# Altium schematic sheet JLINK-OB.SchDoc(JLINK-OB)
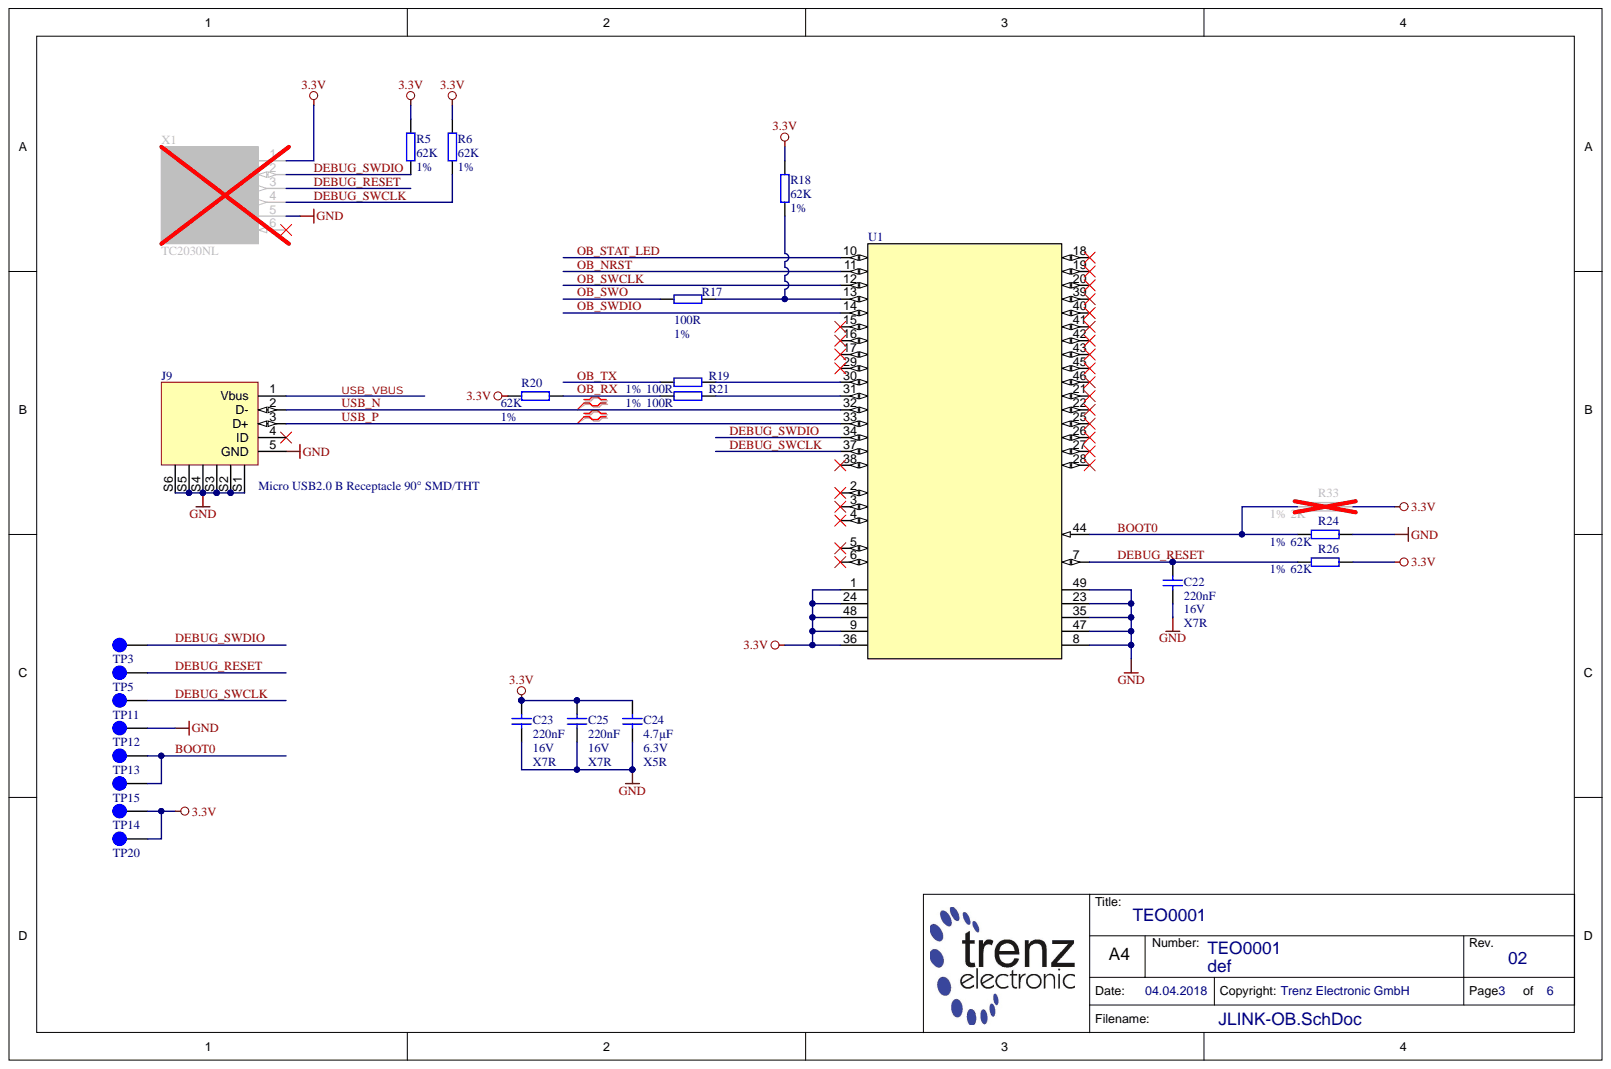
[diagram p0: JLINK-OB.SchDoc]

## Parts
C22  220nF  Technology: X7R  Voltage: 16V  [CAPC1005X55N]
C23  220nF  Technology: X7R  Voltage: 16V  [CAPC1005X55N]
C24  4.7µF  Technology: X5R  Voltage: 6.3V  [CAPC1005X60N]
C25  220nF  Technology: X7R  Voltage: 16V  [CAPC1005X55N]
J9  Micro USB2.0 B Receptacle 90° SMD/THT  [105017-0001]
R5  62K  Tolerance: 1%  [RESC1005X40N]
R6  62K  Tolerance: 1%  [RESC1005X40N]
R17  100R  [RESC1005X40N]
R18  62K  Tolerance: 1%  [RESC1005X40N]
R19  100R  Tolerance: 1%  [RESC1005X40N]
R20  62K  Tolerance: 1%  [RESC1005X40N]
R21  100R  Tolerance: 1%  [RESC1005X40N]
R24  62K  Tolerance: 1%  [RESC1005X40N]
R26  62K  Tolerance: 1%  [RESC1005X40N]
R33  2K  Tolerance: 1%  [RESC1005X40N]
TP3  Testpoint 0.8mm  [TP_0.8mm]  (value not drawn)
TP5  Testpoint 0.8mm  [TP_0.8mm]  (value not drawn)
TP11  Testpoint 0.8mm  [TP_0.8mm]  (value not drawn)
TP12  Testpoint 0.8mm  [TP_0.8mm]  (value not drawn)
TP13  Testpoint 0.8mm  [TP_0.8mm]  (value not drawn)
TP14  Testpoint 0.8mm  [TP_0.8mm]  (value not drawn)
TP15  Testpoint 0.8mm  [TP_0.8mm]  (value not drawn)
TP20  Testpoint 0.8mm  [TP_0.8mm]  (value not drawn)
U1  STM32F072C8U6  [QFN50P700X700X60-49T560X560N]
X1  TC2030NL  [TAGconnectTC2030NL]  (no BOM)

## Nets
3.3V: C23.2 C24.1 C25.2 R5.1 R6.1 R18.1 R20.1 R26.2 R33.2 TP14.1 TP20.1 U1.1(VBAT) U1.9(VDDA) U1.24(VDD) U1.36(VDDIO2) U1.48(VDD) X1.1(VCC)
GND: C22.1 C23.1 C24.2 C25.1 J9.5(GND) J9.S1 J9.S2 J9.S3 J9.S4 J9.S5 J9.S6 R24.2 TP12.1 U1.8(VSSA) U1.23(VSS) U1.35(VSS) U1.47(VSS) U1.49(EP) X1.5(GND)
BOOT0: R24.1 R33.1 TP13.1 TP15.1 U1.44(BOOT0)
DEBUG_RESET: C22.2 R26.1 TP5.1 U1.7(NRST) X1.3(nRESET)
DEBUG_SWCLK: R6.2 TP11.1 U1.37(PA14) X1.4(SWCLK/TCK)
DEBUG_SWDIO: R5.2 TP3.1 U1.34(PA13) X1.2(SWDIO/TMS)
OB_NRST: U1.11(PA1)
OB_RX: R20.2 R21.1
OB_STAT_LED: U1.10(PA0)
OB_SWCLK: U1.12(PA2)
OB_SWDIO: U1.14(PA4)
OB_SWO: R17.1
OB_TX: R19.1
USB_N: J9.2(D-) U1.32(PA11)
USB_P: J9.3(D+) U1.33(PA12)
USB_VBUS: J9.1(Vbus)
NetR17_2: R17.2 R18.2 U1.13(PA3)
NetR19_2: R19.2 U1.30(PA9)
NetR21_2: R21.2 U1.31(PA10)

Not connected: J9 4(ID); U1 2(PC13) 3(PC14-OSC32_IN) 4(PC15-OSC32_OUT) 5(PF0-OSC_IN) 6(PF1-OSC_OUT) 15(PA5) 16(PA6) 17(PA7) 18(PB0) 19(PB1) 20(PB2) 21(PB10) 22(PB11) 25(PB12) 26(PB13) 27(PB14) 28(PB15) 29(PA8) 38(PA15) 39(PB3) 40(PB4) 41(PB5) 42(PB6) 43(PB7) 45(PB8) 46(PB9); X1 6(SWO/TDO)

## Library data of the parts
C22 C23 C25 = 22768: KON 220nF 16V X7R SMD; Database Table Name: C_1005_0402; Mixed: SMT; Value: 220n; AN: 22768; Case: 1005 (0402); Component Kind: Standard; Library Name: TE.DbLib
C24 = 24719: KON 4.7µF 6.3V X5R SMD 20%; Database Table Name: C_1005_0402; Mixed: SMT; Preferred: Yes; Value: 4.7u; AN: 24719; Case: 1005 (0402); Component Kind: Standard; Library Name: TE.DbLib; Tolerance: 20%
J9 = 27993: Database Table Name: CON_IO; Mixed: SMT; AN: 27993; Component Kind: Standard; Description: Micro USB2.0 B Receptacle 90° SMD/THT; H-ART: 105017-0001; Library Name: TE.DbLib; HelpURL: B:\ad\adlib\datasheet\105017-0001
R5 R6 R18 R20 R24 R26 = 23146: WID SMD 62K 1% 0,063W; Database Table Name: R_1005_0402; Mixed: SMT; Preferred: Yes; AN: 23146; Case: 1005 (0402); Component Kind: Standard; Library Name: TE.DbLib; Technology: TK100; Value: 62K
R17 R19 R21 = 22507: WID SMD 100R 1% 0,063W; Database Table Name: R_1005_0402; Mixed: SMT; Preferred: Yes; Value: 100; AN: 22507; Case: 1005 (0402); Component Kind: Standard; Library Name: TE.DbLib; Technology: TK100
  R17: Tolerance: 1%
R33 = 25073: WID SMD 2K 1% 0,063W; Database Table Name: R_1005_0402; Mixed: SMT; AN: 25073; Case: 1005 (0402); Component Kind: Standard; Library Name: TE.DbLib; Technology: TK100; Value: 2K
TP3 TP5 TP11-TP15 TP20 = 26289: Database Table Name: PCB; Mixed: MECH; AN: 26289; Component Kind: Standard; Description: Testpoint 0.8mm; Library Name: TE.DbLib
U1 = 28783: STM32F072C8U6 ARM® 32-bit Cortex®-M0 64KB; Database Table Name: MCU; Mixed: SMT; AN: 28783; Case: QFN48; Component Kind: Standard; H-ART: STM32F072C8U6; Library Name: TE.DbLib; HelpURL: b:\AD\adlib\datasheet\STM32F072x8_B\
X1 = 27592: TC2030NL Tag-Connect Variant 4; Database Table Name: CON_IO; Mixed: THT; AN: 27592; Library Name: TE.DbLib; HelpURL: B:\AD\adlib\datasheet\TAGconnectTC2030
